# Altium schematic sheet main_power_circuit_continue_3.SchDoc(main_power_circuit_continue_3)
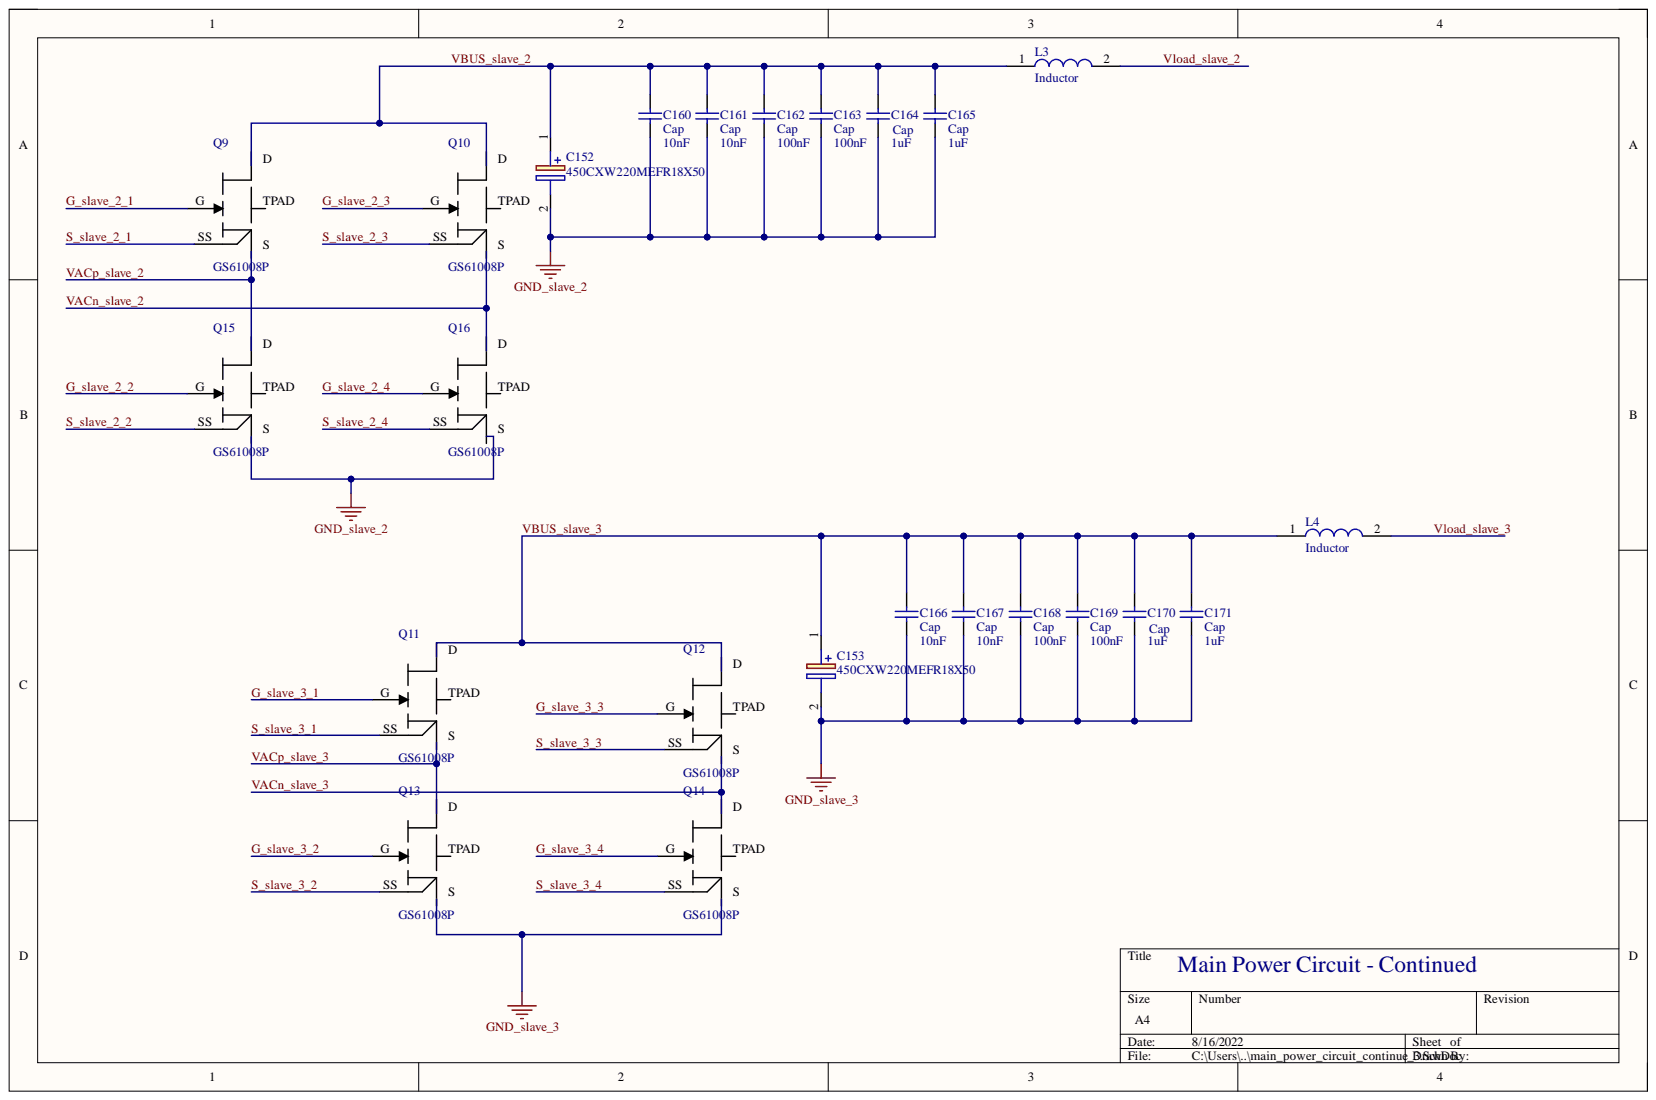
[diagram p0: main_power_circuit_continue_3.SchDoc]

## Parts
C152  450CXW220MEFR18X50  [CAPPRD750W80D1825H5200]
C153  450CXW220MEFR18X50  [CAPPRD750W80D1825H5200]
C160  Cap  value 10nF  [C0805]
C161  Cap  value 10nF  [C0805]
C162  Cap  value 100nF  [C0805]
C163  Cap  value 100nF  [C0805]
C164  Cap  value 1uF  [C0805]
C165  Cap  value 1uF  [C0805]
C166  Cap  value 10nF  [C0805]
C167  Cap  value 10nF  [C0805]
C168  Cap  value 100nF  [C0805]
C169  Cap  value 100nF  [C0805]
C170  Cap  value 1uF  [C0805]
C171  Cap  value 1uF  [C0805]
L3  Inductor  [B82477R4]
L4  Inductor  [B82477R4]
Q9  GS61008P  [GS61008P]
Q10  GS61008P  [GS61008P]
Q11  GS61008P  [GS61008P]
Q12  GS61008P  [GS61008P]
Q13  GS61008P  [GS61008P]
Q14  GS61008P  [GS61008P]
Q15  GS61008P  [GS61008P]
Q16  GS61008P  [GS61008P]

## Nets
GND_slave_2: C152.2 C160.1 C161.1 C162.1 C163.1 C164.1 C165.1 Q15.S Q16.S
GND_slave_3: C153.2 C166.1 C167.1 C168.1 C169.1 C170.1 C171.1 Q13.S Q14.S
G_slave_2_1: Q9.G
G_slave_2_2: Q15.G
G_slave_2_3: Q10.G
G_slave_2_4: Q16.G
G_slave_3_1: Q11.G
G_slave_3_2: Q13.G
G_slave_3_3: Q12.G
G_slave_3_4: Q14.G
S_slave_2_1: Q9.SS
S_slave_2_2: Q15.SS
S_slave_2_3: Q10.SS
S_slave_2_4: Q16.SS
S_slave_3_1: Q11.SS
S_slave_3_2: Q13.SS
S_slave_3_3: Q12.SS
S_slave_3_4: Q14.SS
VACn_slave_2: Q10.S Q16.D
VACn_slave_3: Q12.S Q14.D
VACp_slave_2: Q9.S Q15.D
VACp_slave_3: Q11.S Q13.D
VBUS_slave_2: C152.1 C160.2 C161.2 C162.2 C163.2 C164.2 C165.2 L3.1 Q9.D Q10.D
VBUS_slave_3: C153.1 C166.2 C167.2 C168.2 C169.2 C170.2 C171.2 L4.1 Q11.D Q12.D
Vload_slave_2: L3.2
Vload_slave_3: L4.2

Not connected: Q9 TPAD; Q10 TPAD; Q11 TPAD; Q12 TPAD; Q13 TPAD; Q14 TPAD; Q15 TPAD; Q16 TPAD

## Library data of the parts
C152 C153 = 450CXW220MEFR18X50: Capacitor Polarised; Manufacturer_Name: Rubycon; Component Kind: Standard; Datasheet Link: https://www.mouser.in/ProductDetail/Rubycon/450CXW220MEFR18X50?qs=T3oQrply3y%252BgZzrWksPWmQ%3D%3D; Height: 52mm; Manufacturer_Part_Number: 450CXW220MEFR18X50
C160-C171 = Cap: Capacitor; Component Kind: Standard; LatestRevisionDate: 17-Jul-2002; LatestRevisionNote: Re-released for DXP Platform.; Library Name: Miscellaneous Devices.IntLib; PackageDescription: Radial Cap, Thru-Hole; 2 Leads; 0.3 in Pin Spacing; PackageReference: RAD-0.3; Published: 8-Jun-2000; Signal Integrity: Cap; Simulation: CAP; Publisher: Altium Limited
L3 L4 = B82477R4302M100: Manufacturer_Name: TDK; Component Kind: Standard; Datasheet Link: https://product.tdk.com/system/files/dam/doc/product/inductor/inductor/smd/data_sheet/30/ds/b82477r4.pdf; Description: Inductor; Height: 8.5mm; Library Name: B82477R4302M100.SchLib; Manufacturer_Part_Number: B82477R4302M100
Q9-Q16 = GS61008P: GAN TRANS E-MODE 100V 90A; Component Kind: Standard; Library Name: GANSYS_ALTIUM_SYMBOL_20220201.SchLib
